# Altium schematic sheet Stm32_MiniSystem.SchDoc(Stm32_MiniSystem)
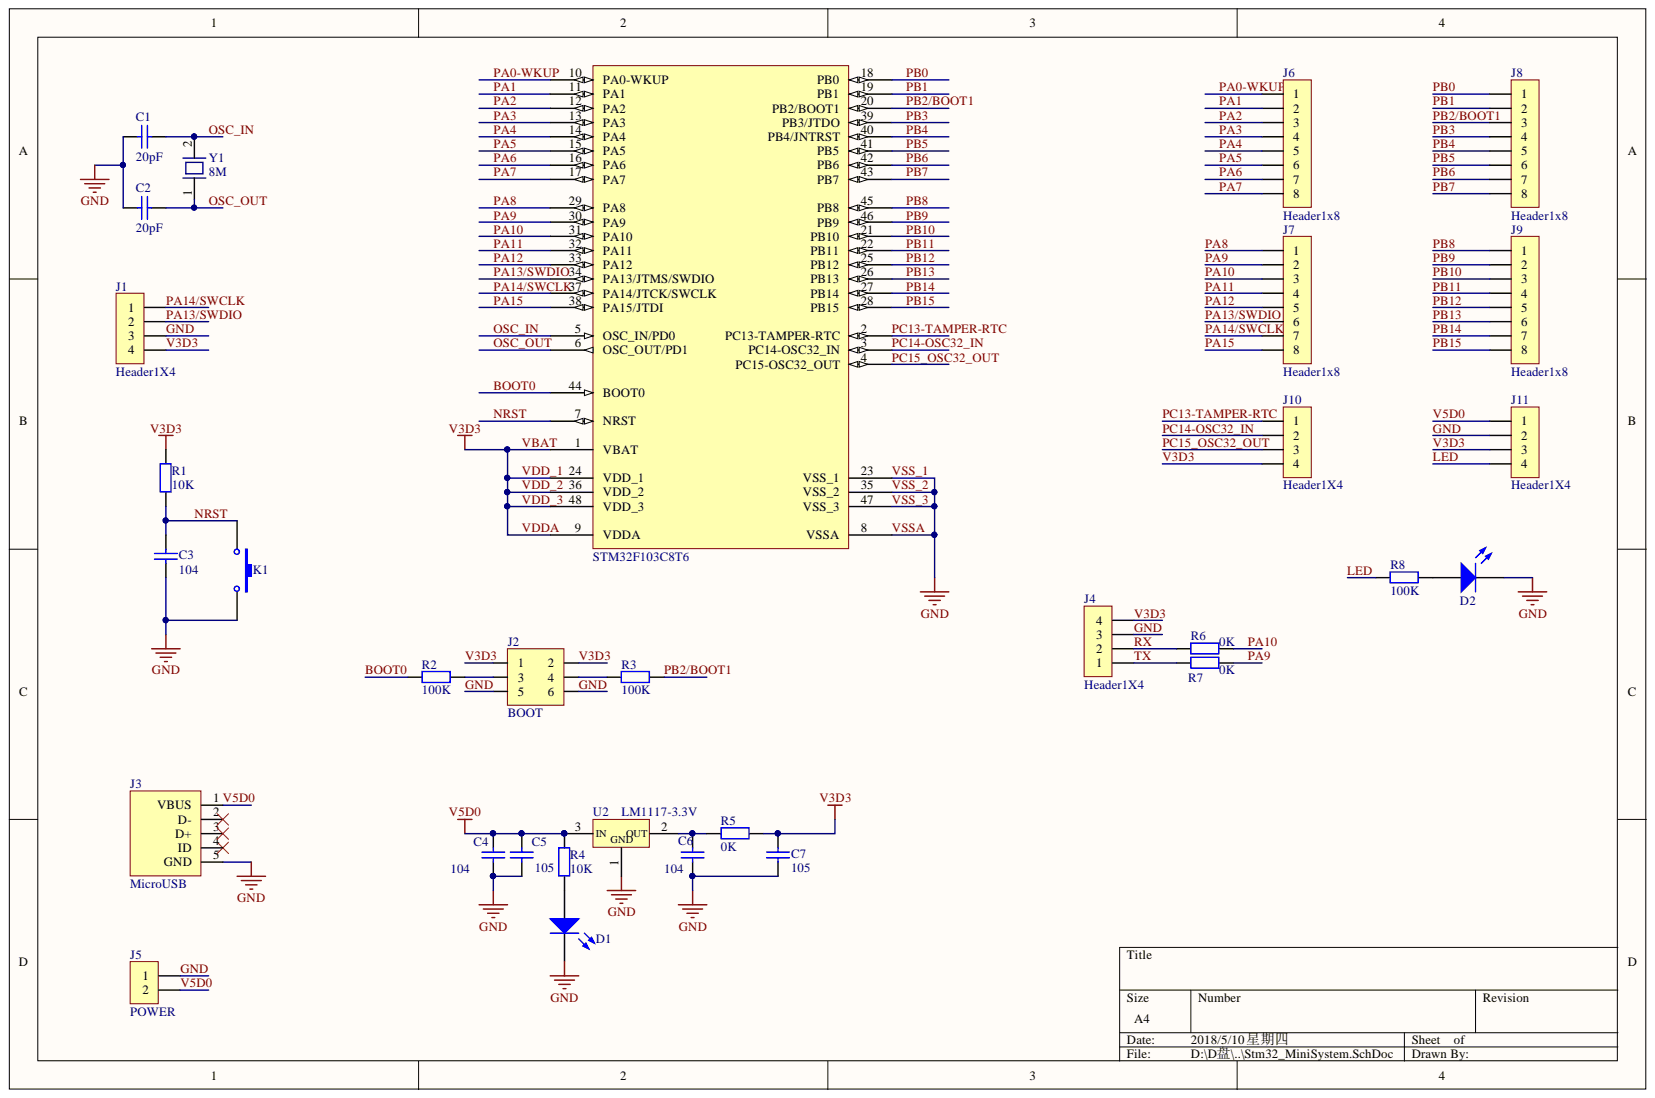
[diagram p0: Stm32_MiniSystem.SchDoc]

## Parts
C1  value 20pF  [1206]  (hidden comment: Cap)
C2  value 20pF  [1206]  (hidden comment: Cap)
C3  value 104  [1206]  (hidden comment: Cap)
C4  value 104  [1206]  (hidden comment: Cap)
C5  value 105  [1206]  (hidden comment: Cap)
C6  value 104  [1206]  (hidden comment: Cap)
C7  value 105  [1206]  (hidden comment: Cap)
D1  D*  [SIP2]
D2  D*  [SIP2]
J1  Header1X4  [SIP4]
J2  BOOT  [SIP2X3]
J3  MicroUSB  [MicroUSB]
J4  Header1X4  [SIP4]
J5  POWER  [SIP2]
J6  Header1x8  [SIP8]
J7  Header1x8  [SIP8]
J8  Header1x8  [SIP8]
J9  Header1x8  [SIP8]
J10  Header1X4  [SIP4]
J11  Header1X4  [SIP4]
K1  Button  [Button]  (value not drawn)
R1  R*  value 10K  [1206]
R2  R*  value 100K  [1206]
R3  R*  value 100K  [1206]
R4  R*  value 10K  [1206]
R5  R*  value 0K  [1206]
R6  R*  value 0K  [1206]
R7  R*  value 0K  [1206]
R8  R*  value 100K  [1206]
STM32F103C8T6  U*  [LQFP48_L]  (value not drawn)
U2  LM1117-3.3V  [SOT223L]
Y1  8M  [XTAL-1]

## Nets
BOOT0: R2.1 STM32F103C8T6.44(BOOT0)
GND: C1.1 C2.2 C3.1 C4.1 C5.1 C6.1 C7.1 D1.2 D2.2 J1.3 J2.5 J2.6 J3.5(GND) J4.3 J5.1 J11.2 K1.2 STM32F103C8T6.8(VSSA) STM32F103C8T6.23(VSS_1) STM32F103C8T6.35(VSS_2) STM32F103C8T6.47(VSS_3) U2.1
LED: J11.4 R8.1
NRST: C3.2 K1.1 R1.1 STM32F103C8T6.7(NRST)
OSC_IN: C1.2 STM32F103C8T6.5(OSC_IN/PD0) Y1.2
OSC_OUT: C2.1 STM32F103C8T6.6(OSC_OUT/PD1) Y1.1
PA0-WKUP: J6.1 STM32F103C8T6.10(PA0-WKUP)
PA1: J6.2 STM32F103C8T6.11(PA1)
PA2: J6.3 STM32F103C8T6.12(PA2)
PA3: J6.4 STM32F103C8T6.13(PA3)
PA4: J6.5 STM32F103C8T6.14(PA4)
PA5: J6.6 STM32F103C8T6.15(PA5)
PA6: J6.7 STM32F103C8T6.16(PA6)
PA7: J6.8 STM32F103C8T6.17(PA7)
PA8: J7.1 STM32F103C8T6.29(PA8)
PA9: J7.2 R7.2 STM32F103C8T6.30(PA9)
PA10: J7.3 R6.2 STM32F103C8T6.31(PA10)
PA11: J7.4 STM32F103C8T6.32(PA11)
PA12: J7.5 STM32F103C8T6.33(PA12)
PA13/SWDIO: J1.2 J7.6 STM32F103C8T6.34(PA13/JTMS/SWDIO)
PA14/SWCLK: J1.1 J7.7 STM32F103C8T6.37(PA14/JTCK/SWCLK)
PA15: J7.8 STM32F103C8T6.38(PA15/JTDI)
PB0: J8.1 STM32F103C8T6.18(PB0)
PB1: J8.2 STM32F103C8T6.19(PB1)
PB2/BOOT1: J8.3 R3.2 STM32F103C8T6.20(PB2/BOOT1)
PB3: J8.4 STM32F103C8T6.39(PB3/JTDO)
PB4: J8.5 STM32F103C8T6.40(PB4/JNTRST)
PB5: J8.6 STM32F103C8T6.41(PB5)
PB6: J8.7 STM32F103C8T6.42(PB6)
PB7: J8.8 STM32F103C8T6.43(PB7)
PB8: J9.1 STM32F103C8T6.45(PB8)
PB9: J9.2 STM32F103C8T6.46(PB9)
PB10: J9.3 STM32F103C8T6.21(PB10)
PB11: J9.4 STM32F103C8T6.22(PB11)
PB12: J9.5 STM32F103C8T6.25(PB12)
PB13: J9.6 STM32F103C8T6.26(PB13)
PB14: J9.7 STM32F103C8T6.27(PB14)
PB15: J9.8 STM32F103C8T6.28(PB15)
PC13-TAMPER-RTC: J10.1 STM32F103C8T6.2(PC13-TAMPER-RTC)
PC14-OSC32_IN: J10.2 STM32F103C8T6.3(PC14-OSC32_IN)
PC15_OSC32_OUT: J10.3 STM32F103C8T6.4(PC15-OSC32_OUT)
RX: J4.2 R6.1
TX: J4.1 R7.1
V3D3: C7.2 J1.4 J2.1 J2.2 J4.4 J10.4 J11.3 R1.2 R5.2 STM32F103C8T6.1(VBAT) STM32F103C8T6.9(VDDA) STM32F103C8T6.24(VDD_1) STM32F103C8T6.36(VDD_2) STM32F103C8T6.48(VDD_3)
V5D0: C4.2 C5.2 J3.1(VBUS) J5.2 J11.1 R4.1 U2.3
NetC6_2: C6.2 R5.1 U2.2
NetD1_1: D1.1 R4.2
NetD2_1: D2.1 R8.2
NetJ2_3: J2.3 R2.2
NetJ2_4: J2.4 R3.1

Not connected: J3 2(D-) 3(D+) 4(ID)

## Library data of the parts
D1 D2 = LED: Component Kind: Standard
  D1: Library Name: relay_sensor.SchLib
  D2: Library Name: MyIntergrateLib.IntLib
J2 = Header2X3: Library Name: relay_sensor.SchLib; Description: Header2X3; Component Kind: Standard
J5 = Header1X2: Library Name: relay_sensor.SchLib; Component Kind: Standard
R1-R8 = Res: Component Kind: Standard
  R1-R4: Library Name: relay_sensor.SchLib
  R5-R8: Library Name: MyIntergrateLib.IntLib
STM32F103C8T6 = LQFP48: LQFP48 7x7mm; Library Name: relay_sensor.SchLib; Component Kind: Standard
Y1 = XTAL-1: Library Name: relay_sensor.SchLib; Component Kind: Standard
C1-C7 = Cap: Library Name: relay_sensor.SchLib; Component Kind: Standard
J1 J4 J10 J11 = Header1X4: Component Kind: Standard
  J1 J4: Library Name: relay_sensor.SchLib
  J10 J11: Library Name: MyIntergrateLib.IntLib
J3 = MicroUSB: Library Name: relay_sensor.SchLib; Component Kind: Standard
J6-J9 = Header1x8: Library Name: relay_sensor.SchLib; Component Kind: Standard
K1 = Button: Library Name: relay_sensor.SchLib; Component Kind: Standard
U2 = LM1117-3.3V: Library Name: relay_sensor.SchLib; Component Kind: Standard
